# Altium schematic sheet 07-GPS.SchDoc
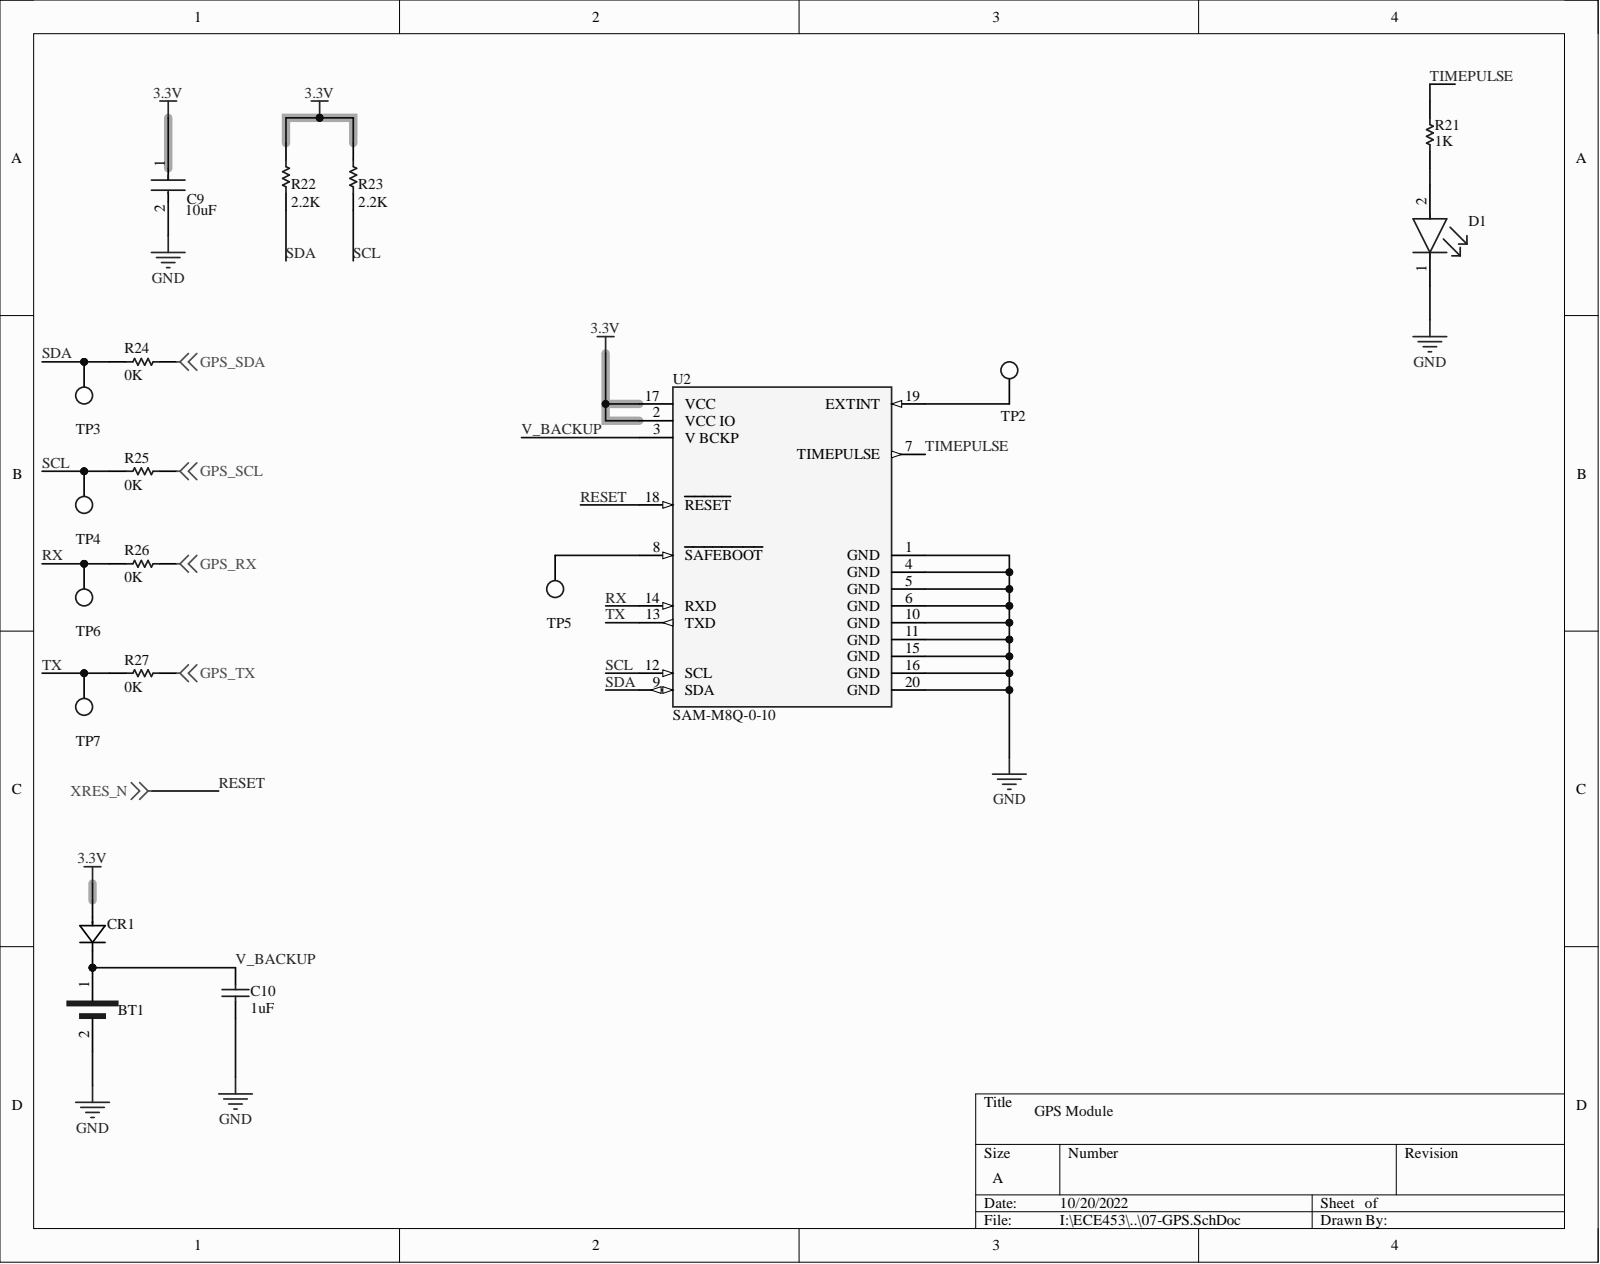
[diagram p0: 07-GPS.SchDoc]

## Parts
BT1  ML414H_IV01E  [SEIKO_ML414H_IV01E]  (value not drawn)
C9  Capacitance: 10uF  [FP-CL21-IPC_B]  (hidden comment: CL21A106KQCLNNC)
C10  1uF  [CAPC2013X145X50LL20T25]
CR1  CUS05S40  [USC_TOS]  (value not drawn)
D1  APHCM2012SYCK-F01  [FP-APHCM2012SYCK-F01-MFG]  (value not drawn)
R21  1K  [RESC2013X60X35ML10T20]
R22  2.2K  [RESC2013X70X40LL20T20]
R23  2.2K  [RESC2013X70X40LL20T20]
R24  0K  [RESC2013X50X30LL20T20]
R25  0K  [RESC2013X50X30LL20T20]
R26  0K  [RESC2013X50X30LL20T20]
R27  0K  [RESC2013X50X30LL20T20]
TP2  TP_1206  [TP-SMC-1206]  (value not drawn)
TP3  TP_1206  [TP-SMC-1206]  (value not drawn)
TP4  TP_1206  [TP-SMC-1206]  (value not drawn)
TP5  TP_1206  [TP-SMC-1206]  (value not drawn)
TP6  TP_1206  [TP-SMC-1206]  (value not drawn)
TP7  TP_1206  [TP-SMC-1206]  (value not drawn)
U2  SAM-M8Q-0-10  [FP-SAM-M8Q-0-10-MFG]

## Nets
3.3V: C9.1 CR1.2 R22.2 R23.2 U2.2(VCC_IO) U2.17(VCC)
GND: BT1.2 C9.2 C10.2 D1.1 U2.1(GND) U2.4(GND) U2.5(GND) U2.6(GND) U2.10(GND) U2.11(GND) U2.15(GND) U2.16(GND) U2.20(GND)
GPS_RX: R26.2
GPS_SCL: R25.2
GPS_SDA: R24.2
GPS_TX: R27.2
RESET: U2.18(RESET)
RX: R26.1 TP6.1 U2.14(RXD)
SCL: R23.1 R25.1 TP4.1 U2.12(SCL)
SDA: R22.1 R24.1 TP3.1 U2.9(SDA)
TIMEPULSE: R21.2 U2.7(TIMEPULSE)
TX: R27.1 TP7.1 U2.13(TXD)
V_BACKUP: BT1.1 C10.1 CR1.1 U2.3(V_BCKP)
NetD1_2: D1.2 R21.1
NetTP2_1: TP2.1 U2.19(EXTINT)
NetTP5_1: TP5.1 U2.8(SAFEBOOT)

## Library data of the parts
BT1 = ML414H_IV01E: Coin, 4.8mm 3V Lithium Battery Rechargeable _Secondary_ 1mAh; MF: Seiko Instruments; MP: ML414H IV01E; Availability: Unavailable; Component Kind: Standard; Library Name: team6.SCHLIB; Package: SOT-89 Seiko; Price: None; SnapEDA_Link: https://www.snapeda.com/parts/ML414H%20IV01E/Seiko%20Instruments/view-part/1015565/?ref=snap
C9 = CMP-13271-003047-1: CL21 Series 0805 10uF 6.3V ±10% Tolerance X5R Multilayer Ceramic Chip Capacitor; Case Code (Imperial): 0805; Case Code (Metric): 2012; Case/Package: 0805; Component Kind: Standard; Depth: 1.25mm; Dielectric: X5R; Height: 0.85mm; Lead Free: Lead Free; Length: 2mm; Library Name: Altium Content Vault; Max Operating Temperature: 85°C; Min Operating Temperature: -55°C; Mount: Surface Mount; Package Quantity: 1; Packaging: Tape and Reel; Radiation Hardening: No; Thickness: 0.037inch; Tolerance: 10%; Voltage Rating: 6.3V; Width: 0.049inch
C10 = CMP-1036-04746-2: Manufacturer: Kemet; Part Number: C0805C105K4PACTU; Component Kind: Standard; Library Name: Altium Content Vault
CR1 = CUS05S40: No Description Available; Mfr_Name: Toshiba Electronic Devices & Storage Corp.; Type: DEV; Component Kind: Standard; Digi-Key_Part_Number_1: CUS05S40H3FCT-ND; Digi-Key_Part_Number_2: CUS05S40H3FDKR-ND; Digi-Key_Part_Number_3: CUS05S40H3FTR-ND; Library Name: team6.SCHLIB; Manufacturer_Part_Number: CUS05S40; RefDes: RefDes; Copyright: Copyright (C) 2022 Ultra Librarian. All rights reserved.
D1 = CMP-44529-000064-1: LED YELLOW CLEAR CHIP SMD; Dominant Wavelength: 590nm; Forward Current: 20mA; Forward Voltage: 2V; Illumination Color: Green; Luminous Intensity: 150mcd; Number of LEDs: 1; Viewing Angle: 110°; Case Code (Imperial): 0805; Case Code (Metric): 2012; Case/Package: 0805; Color: Yellow; Component Kind: Standard; Height: 0.4mm; Lead Free: Lead Free; Length: 2mm; Lens Color: Clear; Lens Style: Clear; Library Name: Altium Content Vault; Max Operating Temperature: 85°C; Min Operating Temperature: -40°C; Mount: Surface Mount; Number of Pins: 2; Package Quantity: 2000; Packaging: Tape and Reel; RoHS Compliant: Yes; Series: APHCM; Test Current: 20mA; Wavelength: 590nm; Width: 1.25mm
R21 = CMP-2100-03671-1: Chip Resistor, 1 KOhm, +/- 1%, 125 mW, -55 to 155 degC, 0805 (2012 Metric), RoHS, Tape and Reel; Manufacturer: Yageo; Max Power Dissipation: 125mW; Resistance: 1KOhm; Temperature Coefficient: 100ppm/degreesC; Voltage Rating: 150V; Case Code (Imperial): 0805; Case Code (Metric): 2012; Case/Package: 0805; Component Kind: Standard; Composition: ThickFilm; Datasheet URL: http://www.yageo.com/NewPortal/yageodocoutput?fileName=/pdf/R-Chip/PYu-RC_Group_51_RoHS_L_5.pdf; Datasheet Version: Rev. 5, 10/2016; Depth: 1.25mm; Height: 0.024inch; Lead Free: LeadFree; Length: 0.079inch; Library Name: Altium Content Vault; Manufacturer URL: http://www.yageo.com/; Max Operating Temperature: 155degC; Military Standard: Not; Min Operating Temperature: -55degC; Number of Pins: 2; Number of Terminations: 2; Package Description: 2-Pin Surface Mounted Device, Body 2 x 1.25 mm; Package Quantity: 1; Package Reference: 0805; Packaging: TapeandReel; Power Rating: 125mW; REACH SVHC: Unknown; RoHSCompliant: true; Termination: SMD/SMT; Tolerance: 1%; Voltage Rating (DC): 150V; Width: 0.049inch
R22 R23 = CMP-2001-00376-1: ERJ-6GEYJ222V; Manufacturer: Panasonic; Component Kind: Standard; Library Name: Altium Content Vault; Part Number: ERJ-6GEYJ222V
R24-R27 = CMP-2001-04896-1: Manufacturer: Vishay Dale; Part Number: CRCW08050000Z0EA; Component Kind: Standard; Library Name: Altium Content Vault
TP2-TP7 = TP_1206: Test Point SMD 1206; Manufacturer: TE Connectivity (Tyco Electronics); Manufacturer Part Number: 1625854-1; Power Rating: N/R; Resistance Value: 0 Ohm; Case Size: 1206; Component Kind: Standard; ComponentLink1Description: https://datasheet.ciiva.com/6439/eng-ds-1773266-a-6439169.pdf; ComponentLink1URL: https://datasheet.ciiva.com/6439/eng-ds-1773266-a-6439169.pdf; ComponentLink2Description: https://datasheet.ciiva.com/30136/1773266-a-30136341.pdf; ComponentLink2URL: https://datasheet.ciiva.com/30136/1773266-a-30136341.pdf; ComponentLink3Description: https://datasheet.ciiva.com/6439/eng-ds-1773266-a-6439080.pdf; ComponentLink3URL: https://datasheet.ciiva.com/6439/eng-ds-1773266-a-6439080.pdf; ComponentLink4Description: https://datasheet.ciiva.com/6439/eng-ds-1773266-a-6439081.pdf; ComponentLink4URL: https://datasheet.ciiva.com/6439/eng-ds-1773266-a-6439081.pdf; ComponentLink5Description: https://datasheet.ciiva.com/6439/eng-ds-1773266-a-6439083.pdf; ComponentLink5URL: https://datasheet.ciiva.com/6439/eng-ds-1773266-a-6439083.pdf; Dimension: 3.2 x 1.6 x 1.25mm; Family: RC; Library Name: Mechanical.SchLib; Mounting: Surface Mount; Operating Temperature: -55 to 125 C; Packaging: Tape and Reel; Part No: 1625854-1; Pricing 1: 1=0.28, 10=0.261, 25=0.224, 50=0.1902, 100=0.1828, 250=0.1641, 500=0.1567, 1000=0.1306 (USD); RoHS: Yes; Stock 1: 31937; Supplier: Digi-Key; Supplier Part Number: A106143CT-ND; Temperature Coefficient: N/R; Terminal Pitch: N/R; Termination Style: N/R; Tolerance: N/R
U2 = CMP-207130-000004-3: RF RX GALILEO 1.575GHZ/1.602GHZ; Manufacturer: uBlox; Automotive Grade: Grade 3; Data Rate: 400kbits/s; Interface: I2C,UART,Other; Max Frequency: 1602MHz; Max Supply Voltage: 3.6V; Min Supply Voltage: 2.7V; Nominal Supply Current: 9.5-32mA; Sensitivity: -165dBm; Automotive: Yes; Category: IC; Component Kind: Standard; ComponentLink2Description: Datasheet; ComponentLink2URL: https://www.u-blox.com/sites/default/files/SAM-M8Q_DataSheet_%28UBX-16012619%29.pdf; Device Class L1: Integrated Circuits (ICs); Device Class L2: RF Semiconductors and Devices; Device Class L3: Transceivers; Digikey Description: RF RX GALILEO 1.575GHZ/1.602GHZ; Footprint Url: https://www.u-blox.com/sites/default/files/SAM-M8Q_HardwareIntegrationManual_%28UBX-16018358%29.pdf; Height: 6.8mm; Lead Free: Yes; Library Name: Altium Content Vault; Manufacturer Part Number: SAM-M8Q-0-10; Octopart: https://octopart.com/sam-m8q-0-10-u-blox-88247750; Package: 0; RoHS: TRUE; Temperature: -40°C to +85°C; Temperature Range High: +85°C; Temperature Range Low: -40°C
